# Altium schematic sheet FaultRev2b.SchDoc
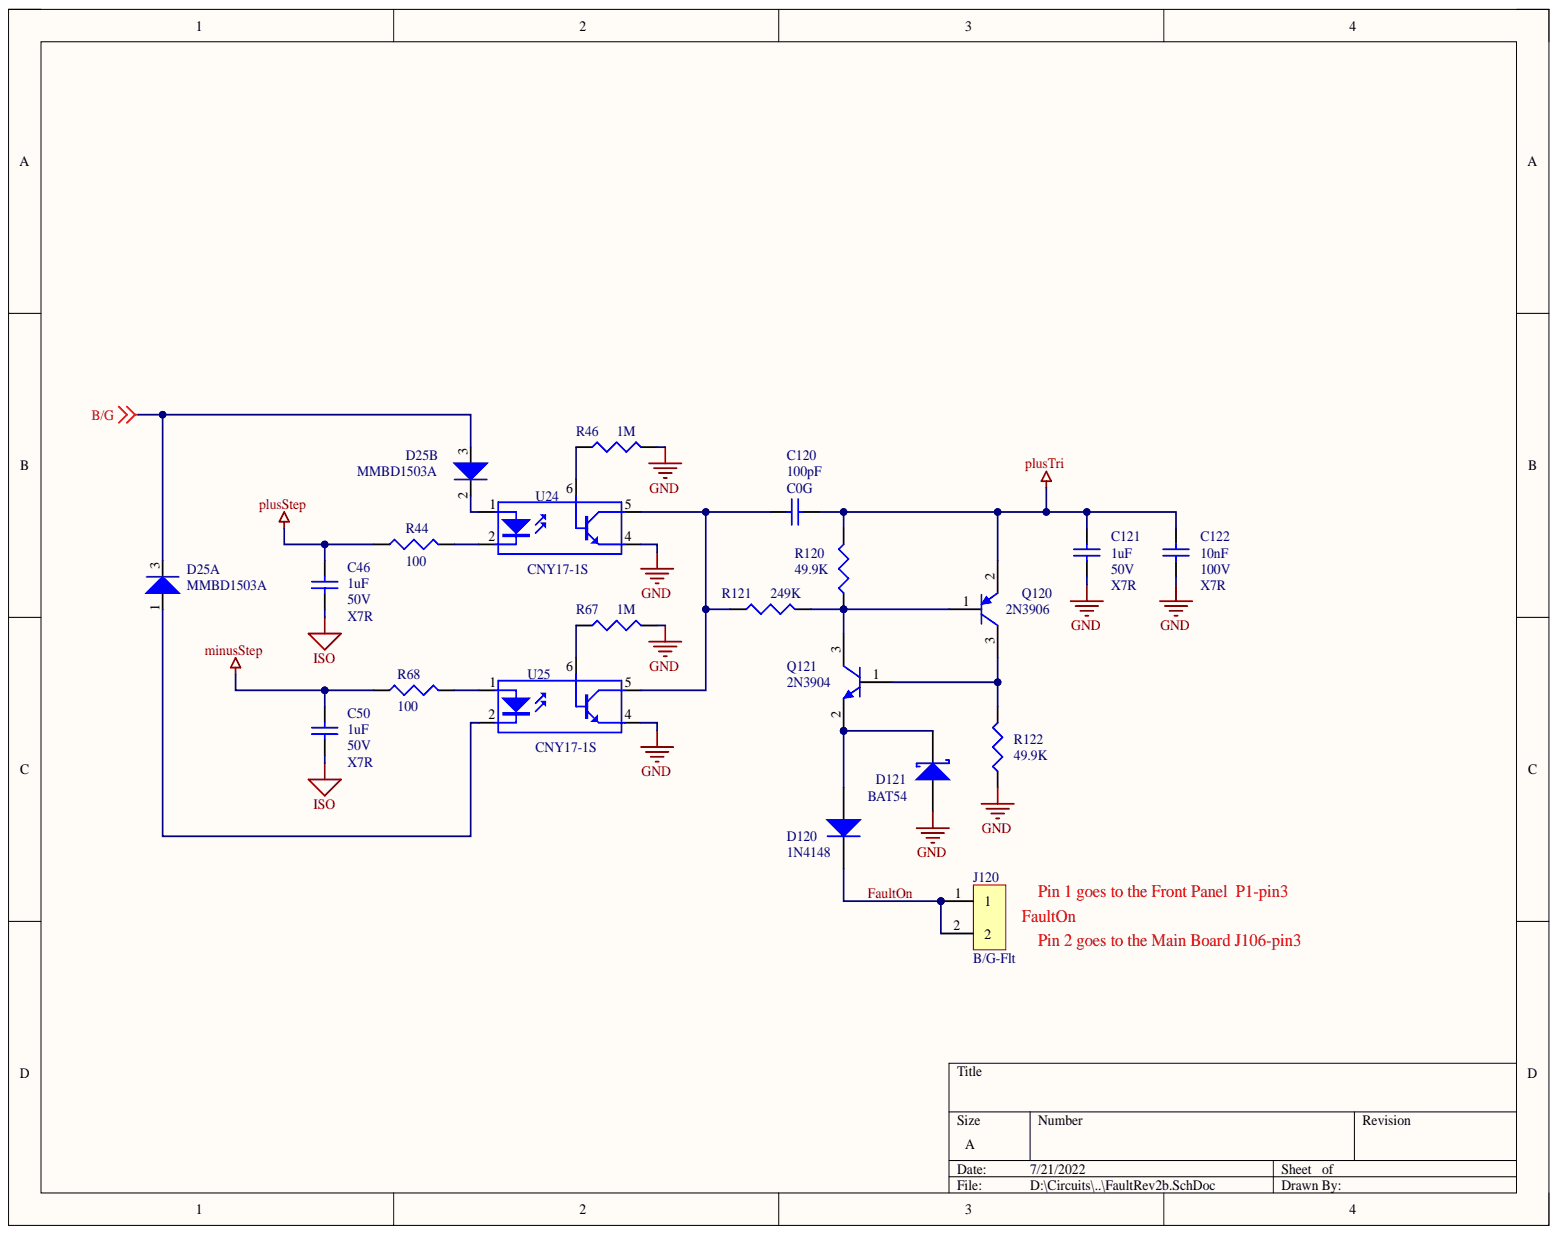
[diagram p0: FaultRev2b.SchDoc]

## Parts
C46  1uF  Dielectric: X7R  Voltage: 50V  [CAPC2012M]
C50  1uF  Dielectric: X7R  Voltage: 50V  [CAPC2012M]
C120  100pF  Dielectric: C0G  [CAPC2012M]
C121  1uF  Dielectric: X7R  Voltage: 50V  [CAPC2012M]
C122  10nF  Dielectric: X7R  Voltage: 100V  [CAPC2012M]
D25  MMBD1503A  [SOT23-3M]  (parts A,B)
D120  1N4148  [SOD123]
D121  BAT54  [SOD123]
J120  B/G-Flt  [DTA-100-2]
Q120  2N3906  [SOT23-3M]
Q121  2N3904  [SOT23-3M]
R44  100  [RESC2012M]
R46  1M  [RESC2012M]
R67  1M  [RESC2012M]
R68  100  [RESC2012M]
R120  49.9K  [RESC2012M]
R121  249K  [RESC2012M]
R122  49.9K  [RESC2012M]
U24  CNY-17-1S  value CNY17-1S  Manufacturer Part Number: CNY17-1S  [SOIC254P1000X6]
U25  CNY-17-1S  value CNY17-1S  Manufacturer Part Number: CNY17-1S  [SOIC254P1000X6]

## Nets
B/G: D25.3
GND: C121.2 C122.2 D121.1 R46.2 R67.2 R122.1 U24.4 U25.4
ISO: C46.2 C50.2
minusStep: C50.1 R68.1
plusStep: C46.1 R44.1
plusTri: C120.1 C121.1 C122.1 Q120.2 R120.2
FaultOn: D120.2 J120.1 J120.2
NetC120_2: C120.2 R121.1 U24.5 U25.5
NetD25_1: D25.1 U25.2
NetD25_2: D25.2 U24.1
NetD120_1: D120.1 D121.2 Q121.2
NetQ120_1: Q120.1 Q121.3 R120.1 R121.2
NetQ120_3: Q120.3 Q121.1 R122.2
NetR44_2: R44.2 U24.2
NetR46_1: R46.1 U24.6
NetR67_1: R67.1 U25.6
NetR68_2: R68.2 U25.1

## Library data of the parts
C46 C50 C121 = Cap: CAP CER 1UF 50V X7R 0805; Manufacturer: Samsung; Manufacturer Part Number: CL21B105KBFNNNE; Dielectric Material: Ceramic; Tolerance: 10%; Capacitance: 1uF; Case Code (Imperial): 0805; Case Code (Metric): 2012; Case/Package: 0805; Component Kind: Standard; Construction: Flat; Depth: 1.25mm; Height: 1.25mm; Length: 2mm; Library Name: Miscellaneous Devices.IntLib; Max Operating Temperature: 125°C; Min Operating Temperature: -55°C; Mount: Surface Mount; Number of Pins: 2; Package Quantity: 1; Packaging: Tape and Reel; Radiation Hardening: No; REACH SVHC: No SVHC; RoHS Compliant: Yes; Series: CL; Signal Integrity: Cap; Simulation: CAP; Supplier: Digi-Key; Supplier Part Number: 1276-1029-6-ND; Termination: SMD/SMT; Thickness: 0.053inch; Value: 1uF; Voltage Rating: 50V; Voltage Rating (DC): 50V; Width: 0.049inch
C120 = Cap: CAP CER 100PF 50V COG 0805; Manufacturer: KEMET; Manufacturer Part Number: C0805C101J5GACTU; Dielectric Material: Ceramic; Dissipation Factor: 0.1%; Temperature Coefficient: 30ppm/°C; Tolerance: 5%; Capacitance: 100pF; Case Code (Imperial): 0805; Case Code (Metric): 2012; Case/Package: 0805; Component Kind: Standard; Construction: Flat; Contact Material: Tin; Contact Plating: Tin,Matte; Depth: 1.25mm; Height: 0.78mm; Lead Free: Lead Free; Lead Pitch: 0.75mm; Length: 2mm; Library Name: Miscellaneous Devices.IntLib; Max Operating Temperature: 125°C; Min Operating Temperature: -55°C; Mount: Surface Mount,PCB; Number of Pins: 2; Package Quantity: 4000; Packaging: Tape and Reel; Radiation Hardening: No; REACH SVHC: No SVHC; RoHS Compliant: Yes; Series: C; Signal Integrity: Cap; Simulation: CAP; Supplier: Digi-Key; Supplier Part Number: 399-1122-6-ND; Termination: SMD/SMT; Thickness: 0.035inch; Value: 100pF; Voltage: 50V; Voltage Rating: 50V; Voltage Rating (DC): 50V; Width: 1.2mm
C122 = Cap: CAP CER 10NF 100V X7R 0805; Manufacturer: Samsung; Manufacturer Part Number: CL21B103KCANNNC; Dielectric Material: Ceramic; Dissipation Factor: 2.5%; Tolerance: 10%; Capacitance: 10nF; Case Code (Imperial): 0805; Case Code (Metric): 2012; Case/Package: 0805; Component Kind: Standard; Construction: Flat; Contact Plating: Tin; Depth: 1.25mm; Height: 0.65mm; Length: 2mm; Library Name: Miscellaneous Devices.IntLib; Material: Ceramic; Max Operating Temperature: 125°C; Min Operating Temperature: -55°C; Mount: Surface Mount; Number of Pins: 2; Package Quantity: 1; Packaging: Tape and Reel; Radiation Hardening: No; REACH SVHC: No SVHC; RoHS Compliant: Yes; Series: CL; Signal Integrity: Cap; Simulation: CAP; Supplier: Digi-Key; Supplier Part Number: 1276-1249-6-ND; Termination: SMD/SMT; Thickness: 0.03inch; Value: 10nF; Voltage Rating: 100V; Voltage Rating (DC): 100V; Width: 0.049inch
D25 = Dual-Si-Series-Separate: DIODE ARRAY GP 200V 200MA SOT23-3; Manufacturer: ON Semiconductor / Fairchild; Capacitance: 4pF; Forward Voltage: 1.15V; Max Forward Surge Current (Ifsm): 2A; Max Reverse Current: 10nA; Power Dissipation: 350mW; Average Rectified Current: 200mA; Case/Package: SOT-23; Component Kind: Standard; Contact Plating: Tin,Matte; Current Rating: 200mA; Forward Current: 200mA; Lead Free: Lead Free; Library Name: MY_Diodes.SchLib; Manufacturer Part Number: MMBD1503A; Max Operating Temperature: 150°C; Max Repetitive Reverse Voltage (Vrrm): 200V; Max Reverse Voltage (DC): 200V; Max Surge Current: 2A; Min Operating Temperature: -55°C; Mount: Surface Mount; Number of Pins: 3; Package Quantity: 1; Packaging: Tape and Reel; Peak Non-Repetitive Surge Current: 2A; Radiation Hardening: No; REACH SVHC: No SVHC; Reverse Voltage: 200V; RoHS Compliant: Yes; Series: -; Supplier: Digi-Key; Supplier Part Number: MMBD1503ADKR-ND; Value: MMBD1503A; Voltage: 200V; Voltage Rating (DC): 200V
D120 = Diode 1N4148: Diode; 500 mA (Surge); 1.0 V (Max.); 400 mW; 450 degC/W; 4 pF (Max.); 4 nS (Max; Manufacturer: Vishay; Manufacturer Part Number: 1N4148W-E3-18; Average Rectified Current: 150mA; Element Configuration: Single; Forward Voltage: 1.2V; Max Power Dissipation: 350mW; Max Repetitive Reverse Voltage (Vrrm): 100V; Max Reverse Current: 100uA; Max Reverse Leakage Current: 5uA; Max Reverse Voltage (DC): 75V; Max Surge Current: 2A; Recovery Time: 4ns; Category: Rectifier Diodes; Component Kind: Standard; ComponentLink1Description: Manufacturer Link; ComponentLink1URL: http://www.fairchildsemi.com/; ComponentLink2Description: Datasheet; ComponentLink2URL: http://www.fairchildsemi.com/ds/1N%2F1N4148.pdf; ComponentLink3Description: https://datasheet.ciiva.com/29885/1n4148w-29885941.pdf?src-supplier=Avnet; ComponentLink3URL: https://datasheet.ciiva.com/29885/1n4148w-29885941.pdf?src-supplier=Avnet; ComponentLink4Description: https://datasheet.ciiva.com/29593/1n4148w-29593111.pdf?src-supplier=Digi-Key; ComponentLink4URL: https://datasheet.ciiva.com/29593/1n4148w-29593111.pdf?src-supplier=Digi-Key; ComponentLink5Description: https://datasheet.ciiva.com/29593/1n4148w-29593112.pdf?src-supplier=Digi-Key; ComponentLink5URL: https://datasheet.ciiva.com/29593/1n4148w-29593112.pdf?src-supplier=Digi-Key; ComponentLink6Description: https://datasheet.ciiva.com/29593/1n4148w-29593113.pdf?src-supplier=Digi-Key; ComponentLink6URL: https://datasheet.ciiva.com/29593/1n4148w-29593113.pdf?src-supplier=Digi-Key; Contact Plating: Tin; Forward Current: 150mA; Height: 1.25mm; Lead Free: Lead Free; Length: 2.85mm; Library Name: Miscellaneous Devices.IntLib; Max Operating Temperature: 150°C; Min Operating Temperature: -55°C; Mount: Surface Mount; Number of Pins: 2; Package Quantity: 10000; Packaging: Tape and Reel; Peak Non-Repetitive Surge Current: 2A; Power Dissipation: 350mW; Pricing 1: 1=0.25000, 10=0.20100, 100=0.10660, 500=0.07012, 1000=0.04768, 2000=0.04301, 5000=0.03740 (USD); Radiation Hardening: No; Reverse Recovery Time: 4ns; RoHS: No; Signal Integrity: 1N4148; Simulation: 1N4148; Stock 1: 103899; Supplier: Digi-Key; Supplier Part Number: 1N4148W-E3-18CT-ND; Value: 1N4148; Weight: 0.000363oz; Width: 1.7mm
D121 = Diode-Schottkey-12: SBAT54T1G Series 30 200 mA Surface Mount Schottky Barrier Diode - SOD-123; Manufacturer: ON Semiconductor; Average Rectified Current: 200mA; Element Configuration: Single; Forward Voltage: 520mV; Max Forward Surge Current (Ifsm): 600mA; Max Power Dissipation: 400mW; Max Repetitive Reverse Voltage (Vrrm): 30V; Max Reverse Current: 500nA; Reverse Recovery Time: 5ns; Category: Schottky Diodes; Component Kind: Standard; ComponentLink1Description: http://www.farnell.com/datasheets/1840528.pdf?src-supplier=Farnell; ComponentLink1URL: http://www.farnell.com/datasheets/1840528.pdf?src-supplier=Farnell; ComponentLink2Description: http://www.mouser.com/ds/2/308/BAT54T1-D-108887.pdf?src-supplier=Mouser; ComponentLink2URL: http://www.mouser.com/ds/2/308/BAT54T1-D-108887.pdf?src-supplier=Mouser; ComponentLink3Description: http://www.onsemi.com/pub/Collateral/BAT54T1-D.PDF?src-supplier=Digi-Key; ComponentLink3URL: http://www.onsemi.com/pub/Collateral/BAT54T1-D.PDF?src-supplier=Digi-Key; ComponentLink4Description: http://www.onsemi.com/pub/Collateral/BAT54T1-D.PDF?src-supplier=Avnet; ComponentLink4URL: http://www.onsemi.com/pub/Collateral/BAT54T1-D.PDF?src-supplier=Avnet; Forward Current: 200mA; Lead Free: Lead Free; Library Name: MY_Diodes.SchLib; Manufacturer Part Number: SBAT54T1G; Max Operating Temperature: 125°C; Max Reverse Voltage (DC): 30V; Min Operating Temperature: -55°C; Mount: Surface Mount; Package Quantity: 3000; Packaging: Tape and Reel; Pricing 1: 1=0.48700, 5=0.48700, 10=0.36400, 100=0.22100 (USD); Reverse Voltage (DC): 30V; RoHS: Yes; RoHS Compliant: Yes; Stock 1: 1490; Supplier: Newark; Supplier Part Number: 50AC7280; Value: BAT54
J120 = 2-Pin: 2.54mm 2pin; Manufacturer: TE Connectivity; Manufacturer Part Number: 640456-2; Approvals: CSA; Backwall/Post Interruptions: No (Without); Circuit Application: Signal; Connector System: Wire-to-Board; Connector Type: Connector,Pin,Plug,Header; Contact Current Rating: 5A; Contact Post Length: 3.56mm; Contact Resistance: 6mR; Contact Style: Straight; Gender: Male; Mating Connector Lock: Yes (With); Max Voltage Rating (AC): 250V; Number of Contacts: 2; PCB Mount Retention: Without; PCB Mounting Orientation: Vertical; PCB Thickness: 0.063inch; Plating Thickness: 3.81um; Tail Length: 0.14inch; Value: 640456-2; Category: Headers and Wire Housings; Color: Natural,White,Beige; Component Kind: Standard; ComponentLink1Description: https://datasheet.ciiva.com/709/eng-cd-640456-w1-709680.pdf?src-supplier=Digi-Key; ComponentLink1URL: https://datasheet.ciiva.com/709/eng-cd-640456-w1-709680.pdf?src-supplier=Digi-Key; ComponentLink2Description: https://datasheet.ciiva.com/3118/eng-ds-82056-mta-0608-3118497.pdf?src-supplier=Avnet; ComponentLink2URL: https://datasheet.ciiva.com/3118/eng-ds-82056-mta-0608-3118497.pdf?src-supplier=Avnet; ComponentLink3Description: https://datasheet.ciiva.com/16401/eng-ds-82056-mta-0608-16401289.pdf?src-supplier=Future+Electronics; ComponentLink3URL: https://datasheet.ciiva.com/16401/eng-ds-82056-mta-0608-16401289.pdf?src-supplier=Future+Electronics; ComponentLink4Description: https://datasheet.ciiva.com/25617/0900766b80027008-25617244.pdf?src-supplier=RSComponents; ComponentLink4URL: https://datasheet.ciiva.com/25617/0900766b80027008-25617244.pdf?src-supplier=RSComponents; ComponentLink5Description: https://datasheet.ciiva.com/14156/04384301-14156820.pdf?src-supplier=Distrelec; ComponentLink5URL: https://datasheet.ciiva.com/14156/04384301-14156820.pdf?src-supplier=Distrelec; ComponentLink6Description: https://datasheet.ciiva.com/11746/0900766b80eb7fdd-11746365.pdf?src-supplier=RSComponents; ComponentLink6URL: https://datasheet.ciiva.com/11746/0900766b80eb7fdd-11746365.pdf?src-supplier=RSComponents; ComponentLink7Description: https://datasheet.ciiva.com/7128/1866390-7128742.pdf?src-supplier=Newark; ComponentLink7URL: https://datasheet.ciiva.com/7128/1866390-7128742.pdf?src-supplier=Newark; ComponentLink8Description: https://datasheet.ciiva.com/16505/eng-ds-82056-mta-0608-16505419.pdf?src-supplier=Avnet+Asia; ComponentLink8URL: https://datasheet.ciiva.com/16505/eng-ds-82056-mta-0608-16505419.pdf?src-supplier=Avnet+Asia; ComponentLink9Description: https://datasheet.ciiva.com/18620/1713017-18620870.pdf?src-supplier=Digi-Key; ComponentLink9URL: https://datasheet.ciiva.com/18620/1713017-18620870.pdf?src-supplier=Digi-Key; ComponentLink10Description: https://datasheet.ciiva.com/16047/1989748-16047438.pdf?src-supplier=Onlinecomponents.com; ComponentLink10URL: https://datasheet.ciiva.com/16047/1989748-16047438.pdf?src-supplier=Onlinecomponents.com; ComponentLink11Description: https://datasheet.ciiva.com/11758/0900766b811d404e-11758587.pdf?src-supplier=RSComponents; ComponentLink11URL: https://datasheet.ciiva.com/11758/0900766b811d404e-11758587.pdf?src-supplier=RSComponents; ComponentLink12Description: https://datasheet.ciiva.com/6746/combiconspring-cagepcbterm-blocks-6746175.pdf?src-supplier=Digi-Key; ComponentLink12URL: https://datasheet.ciiva.com/6746/combiconspring-cagepcbterm-blocks-6746175.pdf?src-supplier=Digi-Key; ComponentLink13Description: https://datasheet.ciiva.com/14607/70055059-14607702.pdf?src-supplier=Allied+Electronics; ComponentLink13URL: https://datasheet.ciiva.com/14607/70055059-14607702.pdf?src-supplier=Allied+Electronics; ComponentLink14Description: https://datasheet.ciiva.com/16051/1988956-16051359.pdf?src-supplier=Onlinecomponents.com; ComponentLink14URL: https://datasheet.ciiva.com/16051/1988956-16051359.pdf?src-supplier=Onlinecomponents.com; ComponentLink15Description: https://datasheet.ciiva.com/26340/567541-da-01-de-printklemme-1988956-250-st-26340451.pdf?src-supplier=Conrad; ComponentLink15URL: https://datasheet.ciiva.com/26340/567541-da-01-de-printklemme-1988956-250-st-26340451.pdf?src-supplier=Conrad; ComponentLink16Description: https://datasheet.ciiva.com/824/combiconcompactseries-824224.pdf?src-supplier=Digi-Key; ComponentLink16URL: https://datasheet.ciiva.com/824/combiconcompactseries-824224.pdf?src-supplier=Digi-Key; ComponentLink17Description: https://datasheet.ciiva.com/14609/70055171-14609100.pdf?src-supplier=Allied+Electronics; ComponentLink17URL: https://datasheet.ciiva.com/14609/70055171-14609100.pdf?src-supplier=Allied+Electronics; Contact Gender: Male; Contact Material: Copper Alloy; Contact Mating Length: 7.49mm; Contact Plating: Tin; Contact Retention: No (Without); Contact Shape: Square; Contact Type: Male Pin; Current Rating: 5A; Depth: 5.72mm; ELV: Compliant; Fastening Type: Friction; Flammability Rating: UL94 V-0; Height: 7.87mm; Housing Color: Natural; Housing Material: Polyester; Lead Free: Lead Free; Lead Pitch: 2.54mm; Length: 7.62mm; Library Name: MY_CONNECTORS.SchLib; Mating Alignment: No (Without); Mating Retention: No (Without); Max Operating Temperature: 221°C; Min Operating Temperature: -67°C; Mount: Through Hole; Mounting Ears: No (Without); Number of Poles: 2; Number of Positions: 2; Number of Rows: 1; Orientation: Straight; Package Quantity: 5000; Packaging: Bulk; Panel Mount Retention: No (Without); PCB Mount Alignment: No (Without); Pitch: 2.54mm; Pricing 1: 1=0.13000, 10=0.10400, 100=0.07700, 500=0.06374, 1000=0.05578, 5000=0.04781, 10000=0.04648 (USD); Radiation Hardening: No; REACH SVHC: No SVHC; RoHS: Yes; RoHS Compliant: Yes; Sealable: No (Without); Series: MTA-100; Shrouded: No (Without); Stock 1: 18986; Strain Relief: No (Without); Supplier: Digi-Key; Supplier Part Number: A1921-ND; Termination: Solder; Voltage Rating: 250V; Voltage Rating (AC): 250V; Working Voltage: 250V
Q120 = 2N3906: TRANS PNP 40V 0.2A SOT23; Manufacturer: ON Semiconductor; Manufacturer Part Number: MMBT3906LT1G; Current - Collector (Ic) (Max): 200mA; DC Current Gain (hFE) (Min) @ Ic, Vce: 100 @ 10mA, 1V; Frequency - Transition: 250MHz; Power - Max: 300mW; Vce Saturation (Max) @ Ib, Ic: 400mV @ 5mA, 50mA; Category: Discrete Semiconductor Products; Component Kind: Standard; Library Name: Miscellaneous Devices.IntLib; Mounting Type: Surface Mount; Package / Case: TO-236-3, SC-59, SOT-23-3; Packaging: Cut Tape (CT); RoHS: RoHS Compliant; Signal Integrity: 2N3906; Simulation: 2N3906; Supplier: Digi-Key; Supplier Device Package: SOT-23-3 (TO-236); Supplier Part Number: MMBT3906LT1GOSCT-ND; Transistor Type: PNP; Value: 2N3906; Voltage - Collector Emitter Breakdown (Max): 40V
Q121 = 2N3904: TRANS NPN 40V 0.2A SOT23; Manufacturer: ON Semiconductor; Manufacturer Part Number: MMBT3904LT1G; Current - Collector (Ic) (Max): 200mA; DC Current Gain (hFE) (Min) @ Ic, Vce: 100 @ 10mA, 1V; Frequency - Transition: 300MHz; Power - Max: 300mW; Vce Saturation (Max) @ Ib, Ic: 300mV @ 5mA, 50mA; Category: Discrete Semiconductor Products; Code_JEDEC: TO-92A; Component Kind: Standard; ComponentLink1Description: Manufacturer Link; ComponentLink1URL: http://www.fairchildsemi.com/; ComponentLink2Description: Datasheet; ComponentLink2URL: http://www.fairchildsemi.com/ds/2N%2F2N3904.pdf; LatestRevisionDate: 15-Jan-2003; LatestRevisionNote: PCB Footprint 'BCY-W3/D4.7' replaced; Library Name: My_Miscellaneous Devices.SchLib; Mounting Type: Surface Mount; Operating Temperature: -55°C ~ 150°C (TJ); Package / Case: TO-236-3, SC-59, SOT-23-3; PackageVersion: Sep-1998; Packaging: Cut Tape (CT); Part Status: Active; RoHS: RoHS Compliant; Signal Integrity: 2N3904; Simulation: 2N3904; Supplier: Digi-Key; Supplier Device Package: SOT-23-3 (TO-236); Supplier Part Number: MMBT3904LT1GOSCT-ND; Transistor Type: NPN; Value: 2N3904; Voltage - Collector Emitter Breakdown (Max): 40V
R44 R68 = Res3: RES 100 OHM 1% 1/4W 0805; Manufacturer: KOA Speer; Manufacturer Part Number: RK73H2ATTD1000F; Max Power Dissipation: 250mW; Power Rating: 125mW; Resistance: 100R; Temperature Coefficient: 100ppm/°C; Voltage Rating: 150V; Case Code (Imperial): 0805; Case Code (Metric): 2012; Case/Package: 0805; Component Kind: Standard; Composition: Thick Film; Construction: Rectangular; Contact Plating: Matte,Tin; Depth: 1.25mm; Features: Automotive AEC-Q200; Height: 0.5mm; Lead Free: Lead Free; Length: 2mm; Library Name: Miscellaneous Devices.IntLib; Max Operating Temperature: 155°C; Military Standard: Not; Min Operating Temperature: -55°C; Mount: Surface Mount; Number of Pins: 2; Number of Terminations: 2; Package Quantity: 5000; Packaging: Tape and Reel; Radiation Hardening: No; REACH SVHC: Unknown; Resistance Tolerance: 1%; Resistor Type: Thick Film; RoHS Compliant: Yes; Series: RK73H; Signal Integrity: Res; Simulation: RESISTOR; Supplier: Digi-Key; Supplier Part Number: 2019-RK73H2ATTD1000FCT-ND; Technology: THICK FILM; Termination: SMD/SMT; Tolerance: 1%; Value: 100; Voltage Rating (DC): 150V; Width: 1.25mm
R46 R67 = Res3: RES 1M OHM 1% 1/8W 0805; Manufacturer: KOA Speer; Manufacturer Part Number: RK73H2ATTD1004F; Power Rating: 125mW; Resistance: 1MR; Temperature Coefficient: 100ppm/°C; Voltage Rating: 150V; Case Code (Imperial): 0805; Case Code (Metric): 2012; Case/Package: 0805; Component Kind: Standard; Construction: Rectangular; Depth: 1.25mm; Height: 0.5mm; Lead Free: Lead Free; Length: 2mm; Library Name: Miscellaneous Devices.IntLib; Max Operating Temperature: 155°C; Military Standard: Not; Min Operating Temperature: -55°C; Number of Pins: 2; Package Quantity: 5000; Packaging: Tape and Reel; REACH SVHC: Unknown; Resistance Tolerance: 1%; Resistor Type: Thick Film; RoHS Compliant: Yes; Series: RK73H; Signal Integrity: Res; Simulation: RESISTOR; Supplier: Digi-Key; Supplier Part Number: 2019-RK73H2ATTD1004FCT-ND; Termination: SMD/SMT; Tolerance: 1%; Value: 1M; Voltage Rating (DC): 150V; Width: 1.25mm
R120 R122 = Res3: RES 49.9K OHM 1% 1/4W 0805; Manufacturer: KOA Speer; Manufacturer Part Number: RK73H2ATTD4992F; Power Rating: 125mW; Resistance: 49.9kR; Temperature Coefficient: 100ppm/°C; Voltage Rating: 150V; Case Code (Imperial): 0805; Case Code (Metric): 2012; Case/Package: 0805; Component Kind: Standard; Construction: Rectangular; Depth: 1.25mm; Height: 0.5mm; Lead Free: Lead Free; Length: 2mm; Library Name: Miscellaneous Devices.IntLib; Max Operating Temperature: 155°C; Military Standard: Not; Min Operating Temperature: -55°C; Mount: Surface Mount; Number of Pins: 2; Package Quantity: 5000; Packaging: Tape and Reel; Resistance Tolerance: 1%; Resistor Type: Thick Film; RoHS Compliant: Yes; Series: RK73H; Signal Integrity: Res; Simulation: RESISTOR; Supplier: Digi-Key; Supplier Part Number: 2019-RK73H2ATTD4992FDKR-ND; Technology: THICK FILM; Termination: SMD/SMT; Tolerance: 1%; Value: 49.9K; Voltage Rating (DC): 150V; Width: 1.25mm
R121 = Res3: RES 249K OHM 1% 1/8W 0805; Manufacturer: KOA Speer; Manufacturer Part Number: RK73H2ATTD2493F; Power Rating: 125mW; Resistance: 249kR; Temperature Coefficient: 100ppm/°C; Voltage Rating: 150V; Case Code (Imperial): 0805; Case Code (Metric): 2012; Case/Package: 0805; Component Kind: Standard; Construction: Rectangular; Depth: 1.25mm; Height: 0.5mm; Lead Free: Lead Free; Length: 2mm; Library Name: Miscellaneous Devices.IntLib; Max Operating Temperature: 155°C; Military Standard: Not; Min Operating Temperature: -55°C; Mount: Surface Mount; Number of Pins: 2; Package Quantity: 5000; Packaging: Tape and Reel; REACH SVHC: Unknown; Resistance Tolerance: 1%; Resistor Type: Thick Film; RoHS Compliant: Yes; Series: RK73H; Signal Integrity: Res; Simulation: RESISTOR; Supplier: Digi-Key; Supplier Part Number: 2019-RK73H2ATTD2493FDKR-ND; Technology: THICK FILM; Termination: SMD/SMT; Tolerance: 1%; Value: 249K; Voltage Rating (DC): 150V; Width: 1.25mm
U24 U25 = CYN17: OPTOISO 5KV TRANS W/BASE 6SMD; Manufacturer: Vishay Lite-On; Collector Emitter Saturation Voltage: 300mV; Collector Emitter Voltage (VCEO): 70V; Current Transfer Ratio: 80%; Fall Time: 10000ns; Forward Current: 60mA; Forward Voltage: 1.45V; Max Collector Current: 150mA; Max Power Dissipation: 250mW; Number of Channels: 1; Reverse Breakdown Voltage: 6V; Case/Package: SMD/SMT; Component Kind: Standard; Lead Free: Lead Free; Library Name: MY_Opto.SchLib; Max Input Current: 60mA; Max Operating Temperature: 100°C; Max Output Voltage: 70V; Min Operating Temperature: -55°C; Mount: Surface Mount; Number of Circuits: 1; Number of Elements: 1; Number of Pins: 6; Output Current per Channel: 150mA; Output Voltage: 70V; Package Quantity: 65; Packaging: Rail/Tube; Power Dissipation: 250mW; Rise Time: 10000ns; Supplier: Digi-Key; Supplier Part Number: 160-1309-5-ND
